# Altium schematic sheet Stm32L4xx.SchDoc(Stm32L4xx)
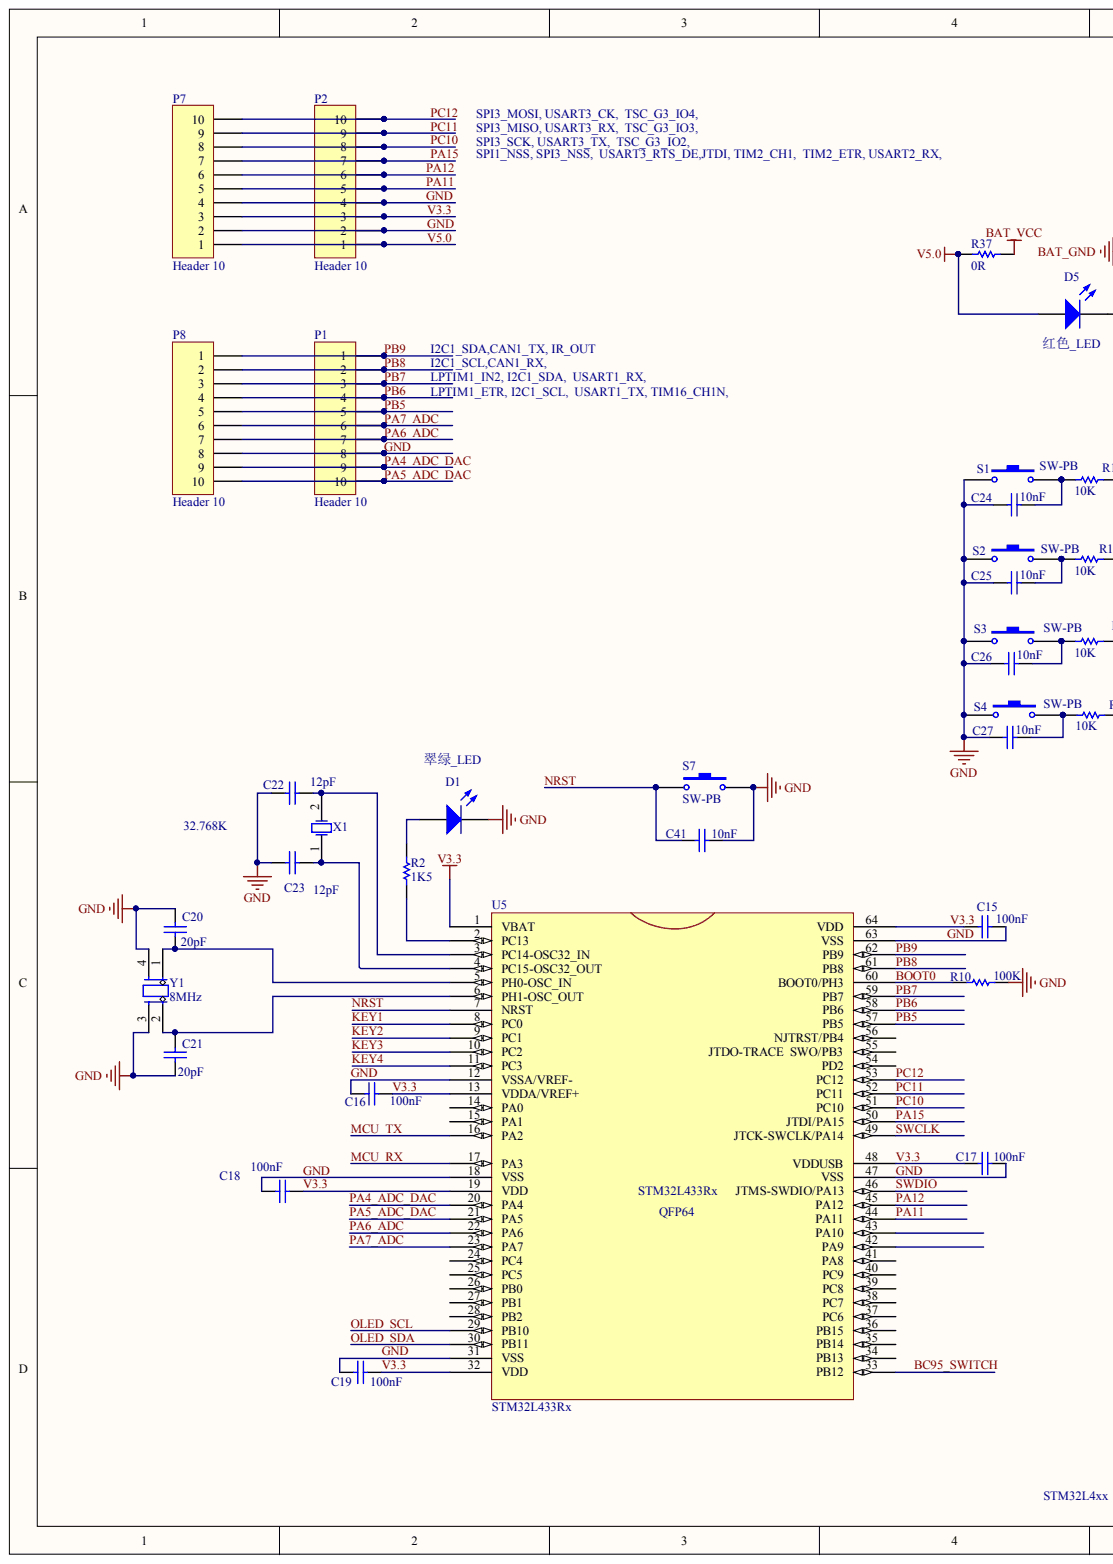
[diagram p0: Stm32L4xx.SchDoc, left]
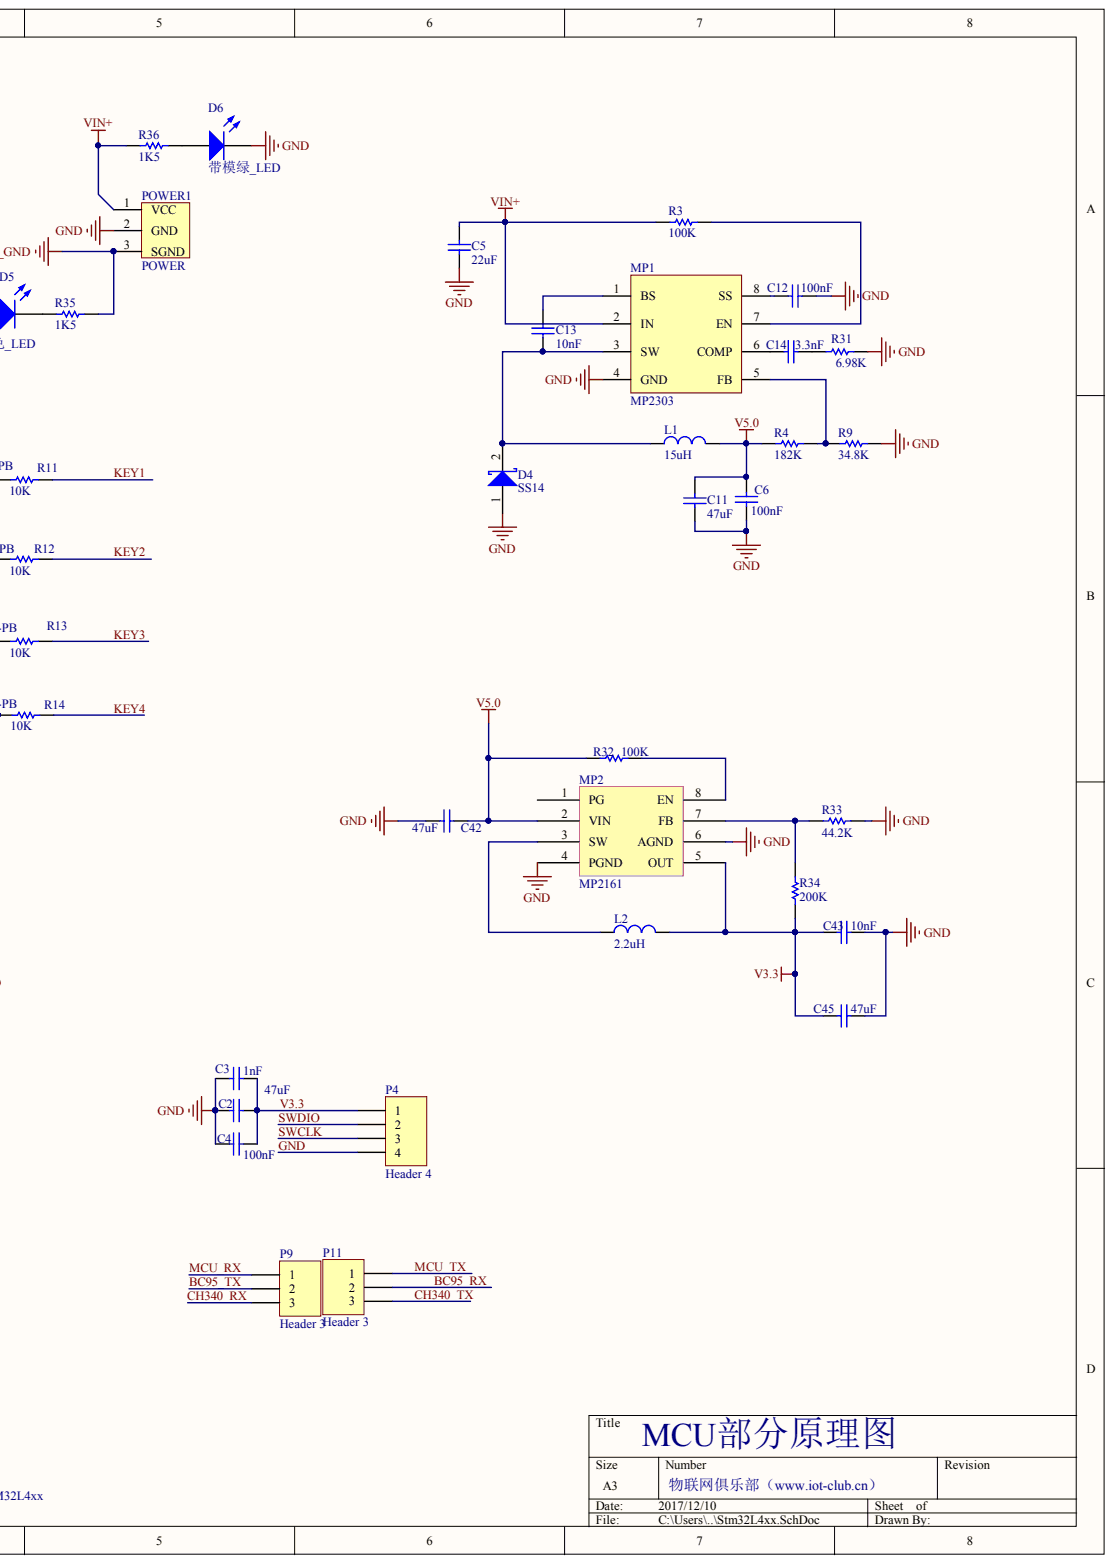
[diagram p1: Stm32L4xx.SchDoc, right]

## Parts
C2  47uF  [LC-1206_C]
C3  1nF  [C0603]
C4  100nF  [C0603]
C5  22uF  [LC-1206_C]
C6  100nF  [C0603]
C11  47uF  [LC-1206_C]
C12  100nF  [C0603]
C13  10nF  [C0603]
C14  3.3nF  [C0603]
C15  100nF  [C0603]
C16  100nF  [C0603]
C17  100nF  [C0603]
C18  100nF  [C0603]
C19  100nF  [C0603]
C20  20pF  [C0603]
C21  20pF  [C0603]
C22  12pF  [C0603]
C23  12pF  [C0603]
C24  10nF  [C0603]
C25  10nF  [C0603]
C26  10nF  [C0603]
C27  10nF  [C0603]
C41  10nF  [C0603]
C42  47uF  [LC-1206_C]
C43  10nF  [C0603]
C45  47uF  [LC-1206_C]
D1  翠绿_LED  [LED_0805]
D4  SS14  [DIODE_1]
D5  红色_LED  [LED_0805]
D6  带模绿_LED  [LED_0805]
L1  15uH  [4x4]
L2  2.2uH  [2520]
MP1  MP2303  [SO-8]
MP2  MP2161  [SOT23-8]
P1  Header 10  [HDR1X10]  (no BOM)
P2  Header 10  [HDR1X10]  (no BOM)
P4  Header 4  [HDR1X4]  (no BOM)
P7  Header 10  [HDR1X10]  (no BOM)
P8  Header 10  [HDR1X10]  (no BOM)
P9  Header 3  [HDR1X3]
P11  Header 3  [HDR1X3]
POWER1  POWER  [DC-005A]
R2  1K5  [R0603]
R3  100K  [R0603]
R4  182K  [R0603]
R9  34.8K  [R0603]
R10  100K  [R0603]
R11  10K  [R0603]
R12  10K  [R0603]
R13  10K  [R0603]
R14  10K  [R0603]
R31  6.98K  [R0603]
R32  100K  [R0603]
R33  44.2K  [R0603]
R34  200K  [R0603]
R35  1K5  [R0603]
R36  1K5  [R0603]
R37  0R  [R1206]
S1  SW-PB  [SMD3*6*2.5]  (no BOM)
S2  SW-PB  [SMD3*6*2.5]  (no BOM)
S3  SW-PB  [SMD3*6*2.5]  (no BOM)
S4  SW-PB  [SMD3*6*2.5]  (no BOM)
S7  SW-PB  [SMD3*6*2.5]  (no BOM)
U5  STM32L433Rx  [LQFP64]  (no BOM)
X1  32.768K  [3215]
Y1  8MHz  [XTAL_1]

## Nets
BAT_GND: POWER1.3 R35.2
BAT_VCC: R37.2
VIN+: C5.2 MP1.2(IN) POWER1.1 R3.1 R36.1
BC95_RX: P11.2
BC95_SWITCH: U5.33(PB12)
BC95_TX: P9.2
BOOT0: R10.1 U5.60(BOOT0/PH3)
CH340_RX: P9.3
CH340_TX: P11.3
GND: C2.1 C3.1 C4.1 C5.1 C6.1 C11.1 C12.2 C15.1 C16.1 C17.1 C18.1 C19.1 C20.2 C21.1 C22.1 C23.1 C24.1 C25.1 C26.1 C27.1 C41.2 C42.1 C43.2 C45.2 D1.2 D4.1 D6.2 MP1.4(GND) MP2.4(PGND) MP2.6(AGND) P1.8 P2.2 P2.4 P4.4 P7.2 P7.4 P8.8 POWER1.2 R9.1 R10.2 R31.2 R33.2 S1.1 S2.1 S3.1 S4.1 S7.2 U5.12(VSSA/VREF-) U5.18(VSS) U5.31(VSS) U5.47(VSS) U5.63(VSS) Y1.3 Y1.4
KEY1: R11.2 U5.8(PC0)
KEY2: R12.2 U5.9(PC1)
KEY3: R13.2 U5.10(PC2)
KEY4: R14.2 U5.11(PC3)
MCU_RX: P9.1 U5.17(PA3)
MCU_TX: P11.1 U5.16(PA2)
NRST: C41.1 S7.1 U5.7(NRST)
OLED_SCL: U5.29(PB10)
OLED_SDA: U5.30(PB11)
PA4_ADC_DAC: P1.9 P8.9 U5.20(PA4)
PA5_ADC_DAC: P1.10 P8.10 U5.21(PA5)
PA6_ADC: P1.7 P8.7 U5.22(PA6)
PA7_ADC: P1.6 P8.6 U5.23(PA7)
PA11: P2.5 P7.5 U5.44(PA11)
PA12: P2.6 P7.6 U5.45(PA12)
PA15: P2.7 P7.7 U5.50(JTDI/PA15)
PB5: P1.5 P8.5 U5.57(PB5)
PB6: P1.4 P8.4 U5.58(PB6)
PB7: P1.3 P8.3 U5.59(PB7)
PB8: P1.2 P8.2 U5.61(PB8)
PB9: P1.1 P8.1 U5.62(PB9)
PC10: P2.8 P7.8 U5.51(PC10)
PC11: P2.9 P7.9 U5.52(PC11)
PC12: P2.10 P7.10 U5.53(PC12)
SWCLK: P4.3 U5.49(JTCK-SWCLK/PA14)
SWDIO: P4.2 U5.46(JTMS-SWDIO/PA13)
V3.3: C2.2 C3.2 C4.2 C15.2 C16.2 C17.2 C18.2 C19.2 C43.1 C45.1 L2.2 MP2.5(OUT) P2.3 P4.1 P7.3 R34.1 U5.1(VBAT) U5.13(VDDA/VREF+) U5.19(VDD) U5.32(VDD) U5.48(VDDUSB) U5.64(VDD)
V5.0: C6.2 C11.2 C42.2 D5.1 L1.2 MP2.2(VIN) P2.1 P7.1 R4.2 R32.1 R37.1
NetC12_1: C12.1 MP1.8(SS)
NetC13_1: C13.1 D4.2 L1.1 MP1.3(SW)
NetC13_2: C13.2 MP1.1(BS)
NetC14_1: C14.1 MP1.6(COMP)
NetC14_2: C14.2 R31.1
NetC20_1: C20.1 U5.5(PH0-OSC_IN) Y1.1
NetC21_2: C21.2 U5.6(PH1-OSC_OUT) Y1.2
NetC22_2: C22.2 U5.3(PC14-OSC32_IN) X1.2
NetC23_2: C23.2 U5.4(PC15-OSC32_OUT) X1.1
NetC24_2: C24.2 R11.1 S1.2
NetC25_2: C25.2 R12.1 S2.2
NetC26_2: C26.2 R13.1 S3.2
NetC27_2: C27.2 R14.1 S4.2
NetD1_1: D1.1 R2.2
NetD5_2: D5.2 R35.1
NetD6_1: D6.1 R36.2
NetL2_1: L2.1 MP2.3(SW)
NetMP1_5: MP1.5(FB) R4.1 R9.2
NetMP1_7: MP1.7(EN) R3.2
NetMP2_7: MP2.7(FB) R33.1 R34.2
NetMP2_8: MP2.8(EN) R32.2
NetR2_1: R2.1 U5.2(PC13)

Not connected: MP2 1(PG); U5 14(PA0) 15(PA1) 24(PC4) 25(PC5) 26(PB0) 27(PB1) 28(PB2) 34(PB13) 35(PB14) 36(PB15) 37(PC6) 38(PC7) 39(PC8) 40(PC9) 41(PA8) 42(PA9) 43(PA10) 54(PD2) 55(SWO/PB3) 56(NJTRST/PB4)

## Library data of the parts
C2-C6 C11-C27 C41-C43 C45 = CAP: Capacitor; Library Name: Devices.IntLib; Component Kind: Standard
D1 D5 D6 = LED1: Typical RED GaAs LED; Simulation: LED1; Published: 8-Jun-2000; PackageReference: LED-1; PackageDescription: LED; 2 Leads; Library Name: Devices.IntLib; LatestRevisionNote: Mech Layer 1 removed.; LatestRevisionDate: 08-Jul-2005; Component Kind: Standard; Publisher: Altium Limited
D4 = D Schottky: Schottky Diode; Simulation: SKYDIODE; Signal Integrity: D Schottky; Published: 8-Jun-2000; PackageReference: SMB; PackageDescription: DO-214-AA/SMB; 2 C-Bend Leads; Body 5.3 x 3.6 mm, inc. leads (LxW); Library Name: Devices.IntLib; LatestRevisionNote: Re-released for DXP Platform.; LatestRevisionDate: 17-Jul-2002; Component Kind: Standard; Code_JEDEC: DO-214-AA; Publisher: Altium Limited
L1 L2 = Inductor: Code_IEC: 1005; Simulation: INDUCTOR; Signal Integrity: Inductor; Published: 8-Jun-2000; PackageReference: 0402-A; PackageDescription: Chip Inductor; Library Name: Devices.IntLib; LatestRevisionNote: IPC-7351 Footprint Added.; LatestRevisionDate: 29-May-2009; Description: Inductor; Component Kind: Standard; Code_JEITA: 0402; Publisher: Altium Limited
P1 P2 P7 P8 = Header 10: Header, 10-Pin; Signal Integrity: Connector; Library Name: Connectors.IntLib; LatestRevisionNote: Re-released for DXP Platform.; LatestRevisionDate: 17-Jul-2002; Publisher: Altium Limited
P4 = Header 4: Header, 4-Pin; Signal Integrity: Connector; Library Name: Connectors.IntLib; LatestRevisionNote: Re-released for DXP Platform.; LatestRevisionDate: 17-Jul-2002; Publisher: Altium Limited
P9 P11 = Header 3: Header, 3-Pin; Signal Integrity: Connector; Library Name: Connectors.IntLib; LatestRevisionNote: Re-released for DXP Platform.; LatestRevisionDate: 17-Jul-2002; Component Kind: Standard; Publisher: Altium Limited
POWER1 = POWER: DC-005; Library Name: Devices.IntLib; Component Kind: Standard
R2-R4 R9-R14 R31-R37 = Res1: Resistor; Value: 1K; Simulation: RESISTOR; Signal Integrity: Res; Published: 8-Jun-2000; PackageReference: AXIAL-0.3; PackageDescription: Axial Device, Thru-Hole; 2 Leads; 0.3 in Pin Spacing; Library Name: Devices.IntLib; LatestRevisionNote: Re-released for DXP Platform.; LatestRevisionDate: 17-Jul-2002; Component Kind: Standard; Publisher: Altium Limited
S1-S4 S7 = SW-PB: Switch; Published: 24-Mar-1999; PackageReference: SPST-2; PackageDescription: Switch; 2 Leads; Library Name: Devices.IntLib; LatestRevisionNote: Re-released for DXP Platform.; LatestRevisionDate: 17-Jul-2002; Publisher: Altium Limited
U5 = STM32L433Rx: 超低功耗高性能ARM Cortex-M4 32-bit MCU+FPU 80MHz 100DMIPS; Library Name: IC.SchLib
X1 = XTAL_FC135: XTAL; Library Name: Devices.IntLib; Component Kind: Standard
Y1 = XTAL_4: XTAL; Library Name: Devices.IntLib; Component Kind: Standard
MP1 = MP2303: Library Name: IC.IntLib; Component Kind: Standard
MP2 = MP2161: Library Name: IC.IntLib; Component Kind: Standard
